# Altium schematic sheet DC-DC.SchDoc
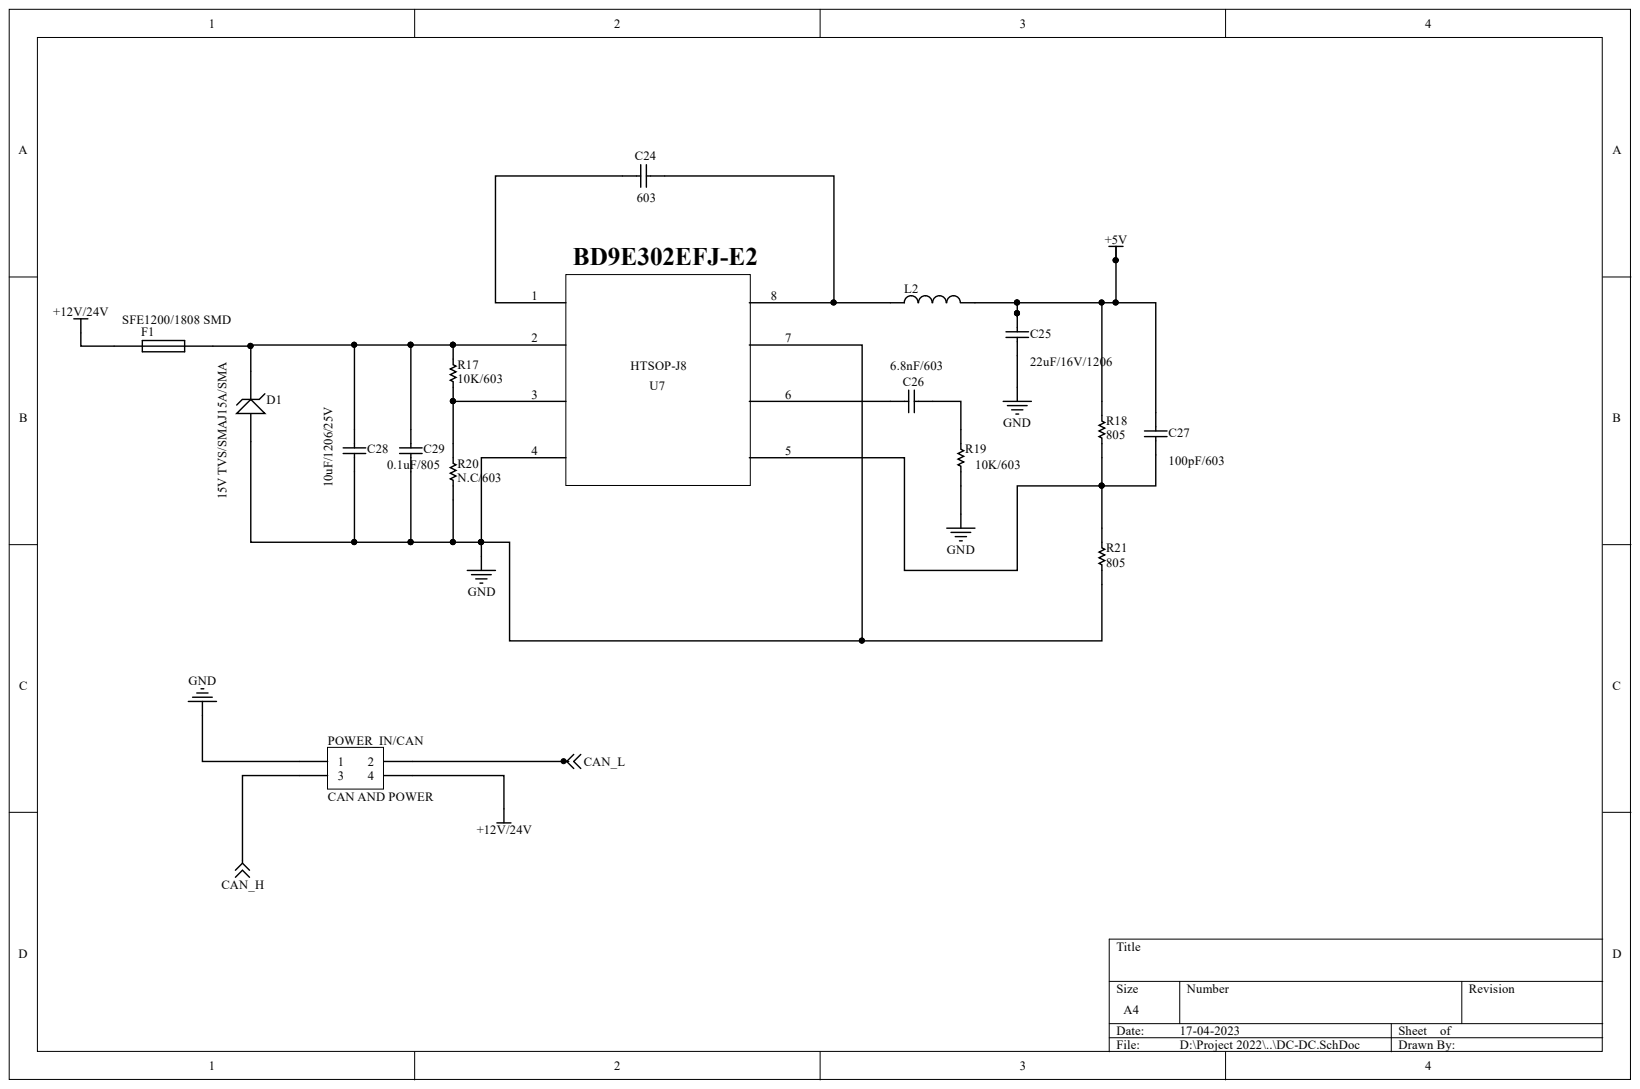
[diagram p0: DC-DC.SchDoc]

## Parts
C24  value 603  [RAD-0.3]
C25  value 22uF/16V/1206  [RAD-0.3]
C26  value 6.8nF/603  [RAD-0.3]
C27  value 100pF/603  [RAD-0.3]
C28  value 10uF/1206/25V  [RAD-0.3]
C29  value 0.1uF/805  [RAD-0.3]
D1  15V TVS/SMAJ15A/SMA  [DIODE-0.7]
F1  SFE1200/1808 SMD  [PIN-W2/E2.8]
L2  (no value)  [0402-A]
POWER_IN/CAN  CAN AND POWER  [HDR2X2H]
R17  10K/603  [AXIAL-0.3]
R18  805  [AXIAL-0.3]
R19  10K/603  [AXIAL-0.3]
R20  N.C/603  [AXIAL-0.3]
R21  805  [AXIAL-0.3]

## Nets
+5V: C25.2 C27.2 L2.2 R18.2
+12V/24V: F1.1 POWER_IN/CAN.4
CAN_H: POWER_IN/CAN.3
CAN_L: POWER_IN/CAN.2
GND: C25.1 C28.1 C29.1 D1.1 POWER_IN/CAN.1 R19.1 R20.1 R21.1
NetC24_2: C24.2 L2.1
NetC26_2: C26.2 R19.2
NetC27_1: C27.1 R18.1 R21.2
NetC28_2: C28.2 C29.2 D1.2 F1.2 R17.2
NetR17_1: R17.1 R20.2

Not connected: C24 1; C26 1

## Library data of the parts
C24-C29 = Cap: Capacitor; Component Kind: Standard; LatestRevisionDate: 17-Jul-2002; LatestRevisionNote: Re-released for DXP Platform.; Library Name: Miscellaneous Devices.IntLib; PackageDescription: Radial Cap, Thru-Hole; 2 Leads; 0.3 in Pin Spacing; PackageReference: RAD-0.3; Published: 8-Jun-2000; Signal Integrity: Cap; Simulation: CAP; Publisher: Altium Limited
D1 = D Zener: Zener Diode; Component Kind: Standard; LatestRevisionDate: 17-Jul-2002; LatestRevisionNote: Re-released for DXP Platform.; Library Name: Miscellaneous Devices.IntLib; PackageDescription: Diode, Thru-Hole; 2 Leads; 0.7 in Pin Spacing; PackageReference: DIODE-0.7; Published: 8-Jun-2000; Signal Integrity: D Zener; Simulation: ZENER; Publisher: Altium Limited
F1 = Fuse 1: Fuse; Component Kind: Standard; LatestRevisionDate: 17-Jul-2002; LatestRevisionNote: Re-released for DXP Platform.; Library Name: Miscellaneous Devices.IntLib; PackageDescription: Thru-Hole; 2 Leads; PackageReference: PIN-W2/E2.8; Published: 8-Jun-2000; Simulation: FUSE; Publisher: Altium Limited
L2 = Inductor: Code_IEC: 1005; Code_JEITA: 0402; Component Kind: Standard; Description: Inductor; LatestRevisionDate: 29-May-2009; LatestRevisionNote: IPC-7351 Footprint Added.; Library Name: Miscellaneous Devices.IntLib; PackageDescription: Chip Inductor; PackageReference: 0402-A; Published: 8-Jun-2000; Signal Integrity: Inductor; Simulation: INDUCTOR; Publisher: Altium Limited
POWER_IN/CAN = Header 2X2H: Header, 2-Pin, Dual row, Right Angle; Component Kind: Standard; LatestRevisionDate: 17-Jul-2002; LatestRevisionNote: Re-released for DXP Platform.; Library Name: Miscellaneous Connectors.IntLib; Signal Integrity: Connector; Publisher: Altium Limited
R17-R21 = Res1: Resistor; Component Kind: Standard; LatestRevisionDate: 17-Jul-2002; LatestRevisionNote: Re-released for DXP Platform.; Library Name: Miscellaneous Devices.IntLib; PackageDescription: Axial Device, Thru-Hole; 2 Leads; 0.3 in Pin Spacing; PackageReference: AXIAL-0.3; Published: 8-Jun-2000; Signal Integrity: Res; Simulation: RESISTOR; Publisher: Altium Limited
